# Altium schematic sheet [12] SD.SchDoc(BLK4)
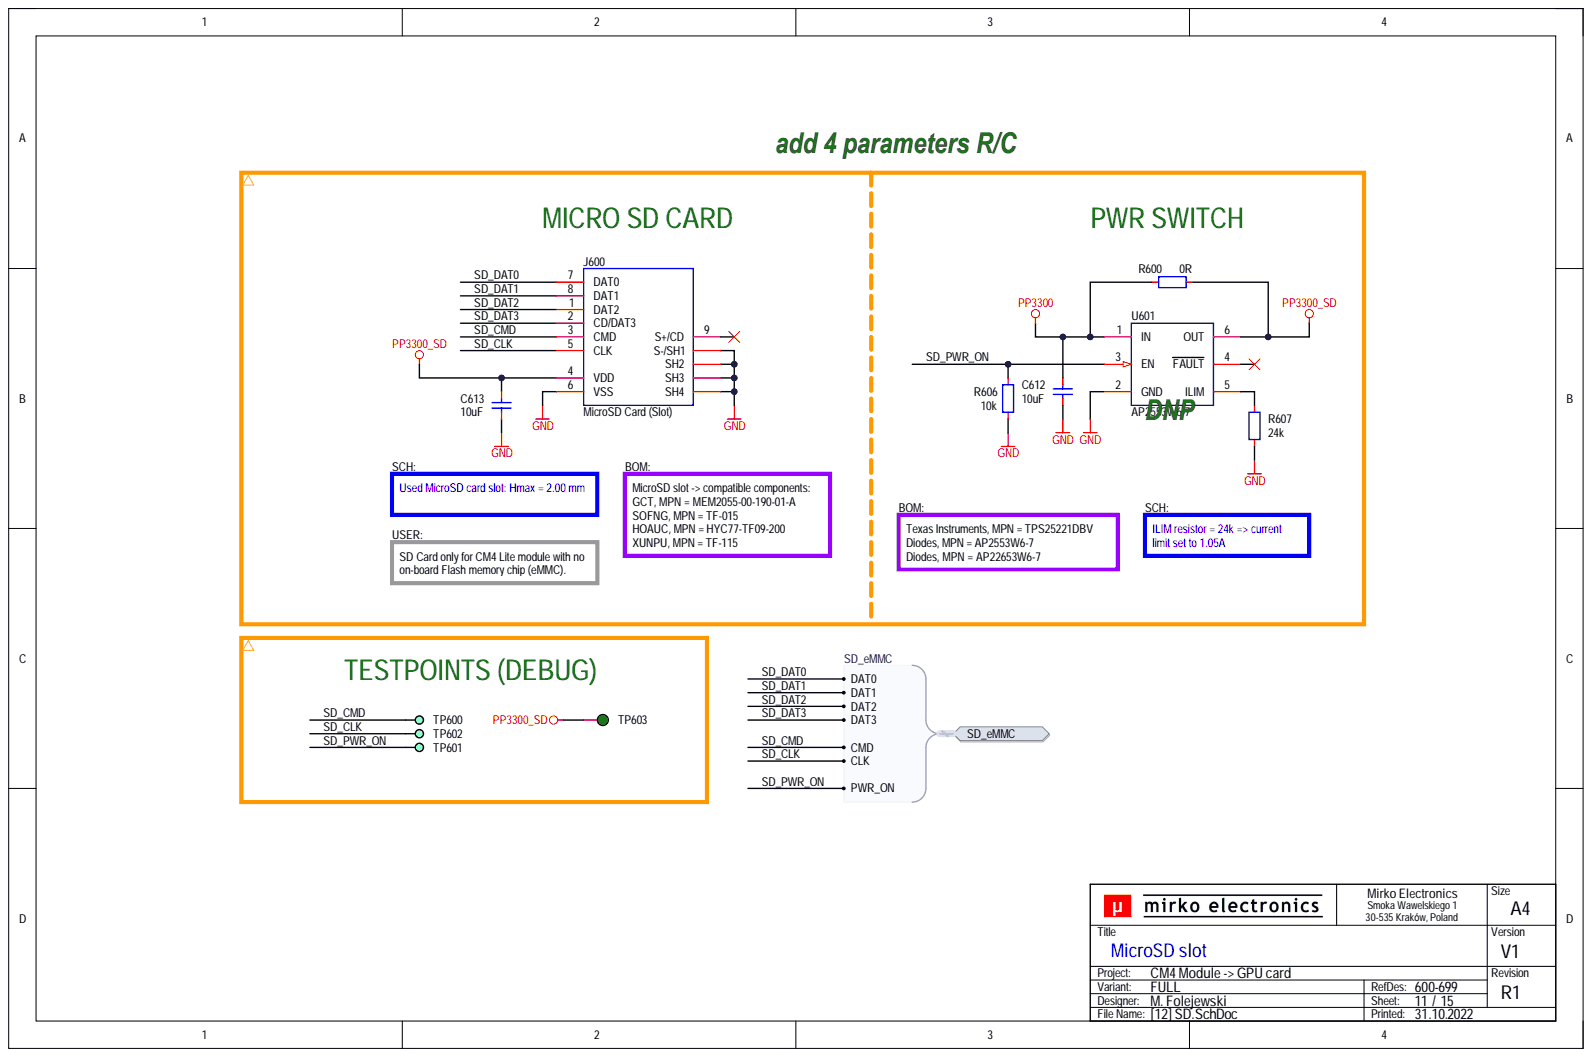
[diagram p0: [12] SD.SchDoc]

## Parts
C612  10uF  [CAP_0603_R]
C613  10uF  [CAP_0603_R]
J600  MicroSD Card (Slot)  Component Subtype: MicroSD Card  [CON_GCT_MEM2055_R]
R600  0R  [RES_0603_R]
R606  10k  [RES_0603_R]
R607  24k  [RES_0603_R]
TP600  DEBUG  [PCB_TP_DBG_50]  (value not drawn)  (no BOM)
TP601  DEBUG  [PCB_TP_DBG_50]  (value not drawn)  (no BOM)
TP602  DEBUG  [PCB_TP_DBG_50]  (value not drawn)  (no BOM)
TP603  ICT  [PCB_TP_ICT_100]  (value not drawn)  (no BOM)
U601  AP2553W6-7  [PKG_SOT23-6]

## Ports
SD_eMMC, SD_eMMC.CLK, SD_eMMC.CMD, SD_eMMC.DAT0, SD_eMMC.DAT1, SD_eMMC.DAT2, SD_eMMC.DAT3, SD_eMMC.PWR_ON

## Nets
GND: C612.1 C613.1 J600.6(VSS) J600.SH1(S-/SH1) J600.SH2 J600.SH3 J600.SH4 R606.1 R607.1 U601.2(GND)
PP3300: C612.2 R600.2 U601.1(IN)
PP3300_SD: C613.2 J600.4(VDD) R600.1 TP603.1 U601.6(OUT)
SD_CLK: J600.5(CLK) TP602.1 ; port SD_eMMC
SD_CMD: J600.3(CMD) TP600.1 ; port SD_eMMC
SD_DAT0: J600.7(DAT0) ; port SD_eMMC
SD_DAT1: J600.8(DAT1) ; port SD_eMMC
SD_DAT2: J600.1(DAT2) ; port SD_eMMC
SD_DAT3: J600.2(CD/DAT3) ; port SD_eMMC
SD_PWR_ON: R606.2 TP601.1 U601.3(EN) ; port SD_eMMC
NetR607_2: R607.2 U601.5(ILIM)

Not connected: J600 9(S+/CD); U601 4(FAULT)

## Library data of the parts
C612 C613 = CAP-0023: Capacitor; MLCC; GENERIC; TBD; TBD; TBD; TBD; SMD; 0603 (1608);; Database Table Name: CAPACITOR$; Component Kind: Standard; Component Subtype: MLCC; Component Type: Capacitor; Manufacturer: GENERIC; Mounting Type: SMD; MPN: GENERIC; Package (Case): 0603 (1608); Supplier: ?; Value: 10uF; Library Name: MirFol_db_local.DbLib
J600 = CON_GCT_MEM2055: Connector; MicroSD Card Connector;; Manufacturer: TF-015; Package (Case): SMD; Component Kind: Standard; Component Type: Card Socket; Mounting Type: SMD; MPN: TF-015; Supplier: ?; Value: MicroSD Card (Slot); Library Name: MirFol_lib.SchLib
R600 R607 = RES-0054: Resistor; Thick Film; GENERIC; TBD; TBD; TBD; TBD; TBD; SMD; 0603 (1608);; Database Table Name: RESISTOR$; Component Kind: Standard; Component Subtype: Thick Film; Component Type: Resistor; Manufacturer: GENERIC; Mounting Type: SMD; MPN: GENERIC; Package (Case): 0603 (1608); Supplier: ?; Library Name: MirFol_db_local.DbLib
  R600: Value: 0R
  R607: Value: 24k
R606 = RES-0028: Resistor; Thick Film; 10k; 1%; 100mW; 100PPM; 75V; Standard; SMD; 0603 (1608);; Manufacturer: Yageo Corporation; MPN: RC0603FR-0710KL; Database Table Name: RESISTOR$; Component Kind: Standard; Component Subtype: Thick Film; Component Type: Resistor; Mounting Type: SMD; Package (Case): 0603 (1608); Supplier: ?; Value: 10k; Library Name: MirFol_db_local.DbLib
TP600-TP602 = PCB_TP_DBG: PCB Marking; Test Point (Debug);; Component Subtype: -; Component Type: Testpoint; Mounting Type: -; Supplier: ?; Value: DEBUG; Library Name: MirFol_lib.SchLib
TP603 = PCB_TP_ICT: Test Point (ICT); Specification: Testpoint (for ICT), SMD pad; Component Type: Testpoint (for ICT); Manufacturer: -; Mounting Type: Non component; MPN: -; Value: ICT; Library Name: MirFol_lib.SchLib
U601 = ICC_TXI_TPS25221_DBV: IC; Current Limited Switch;; Manufacturer: Diodes Incorporated; Package (Case): SOT26; Component Kind: Standard; Component Subtype: Current Limited Switch; Component Type: IC; Mounting Type: SMD; MPN: AP2553W6-7; Supplier: ?; Value: AP2553W6-7; Library Name: MirFol_lib.SchLib
